# Altium schematic sheet Main.SchDoc(Main)
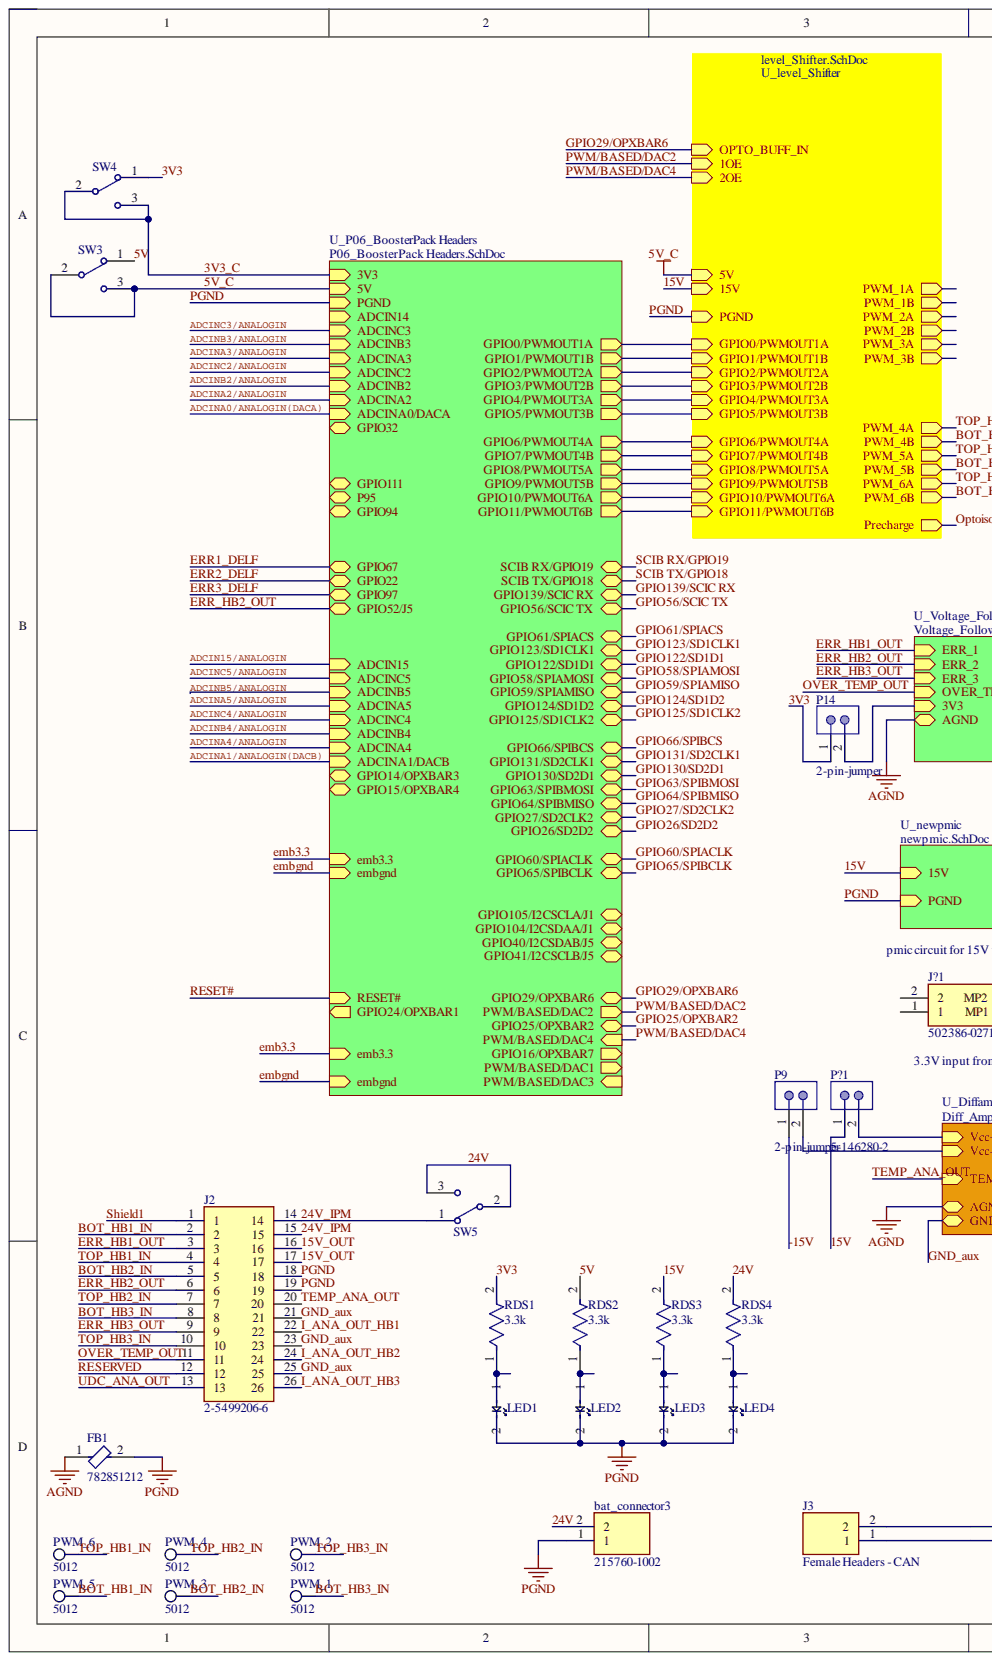
[diagram p0: Main.SchDoc, left]
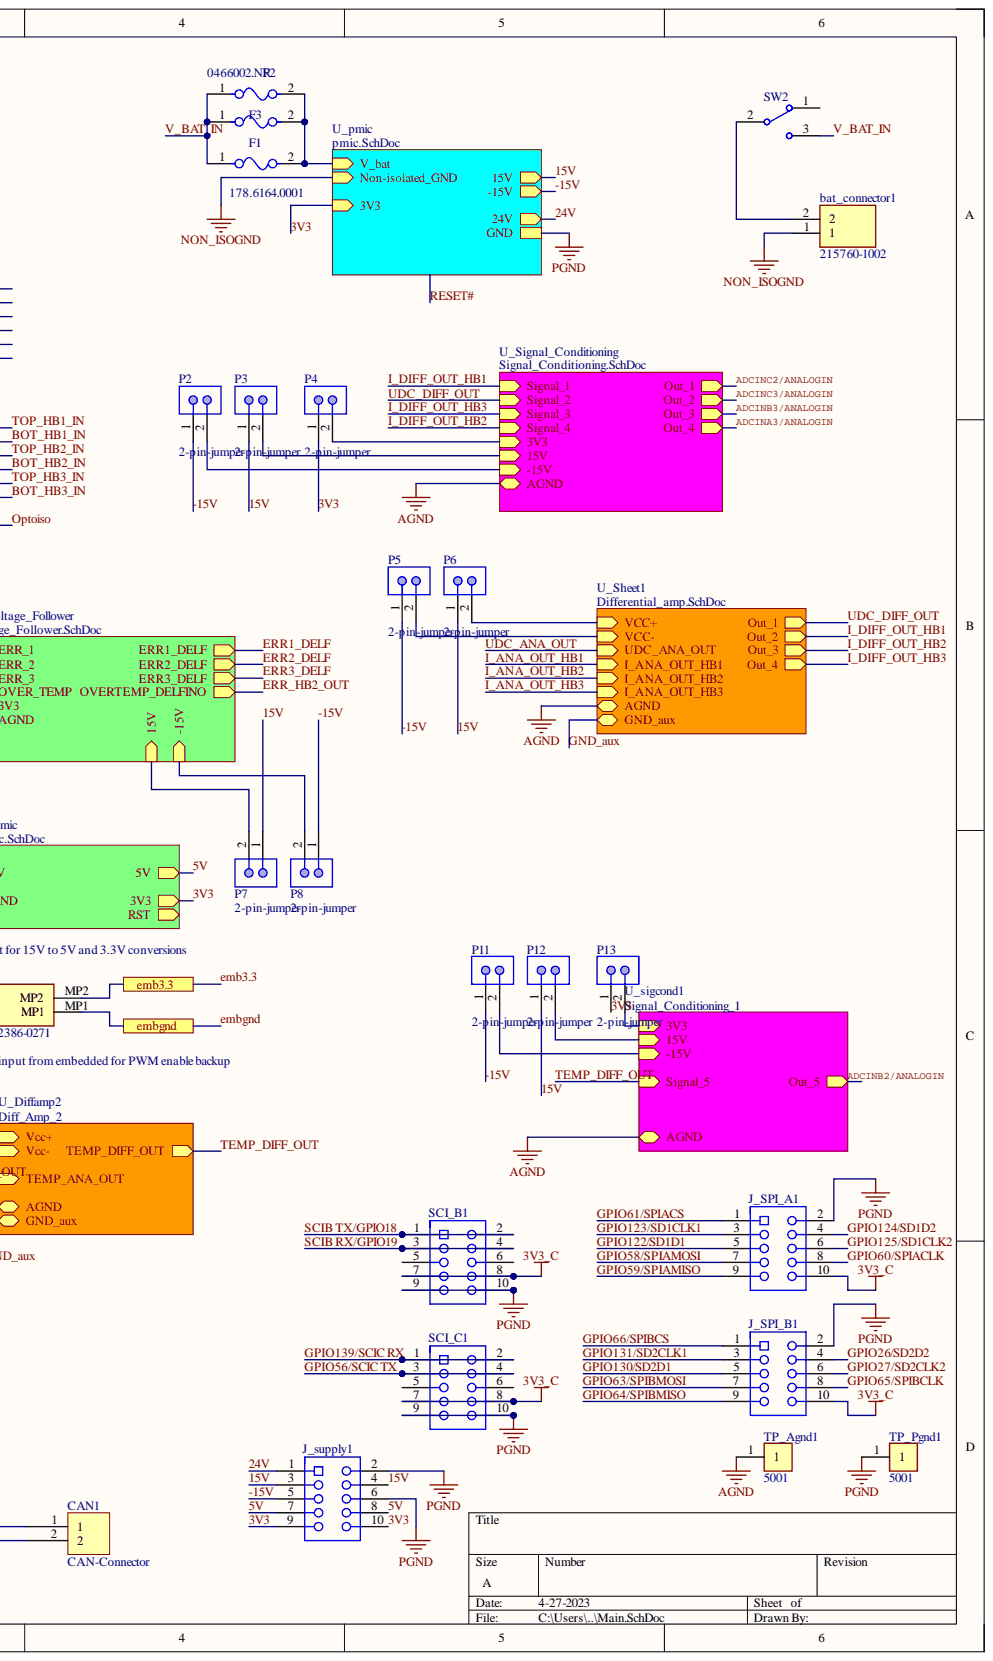
[diagram p1: Main.SchDoc, right]

## Parts
bat_connector1  215760-1002  [215760-YY02]
bat_connector3  215760-1002  [215760-YY02]
CAN1  CAN-Connector  [PCBComponent_1]
F1  178.6164.0001  [FP-178_6164_0001-MFG]
F2  0466002.NR  [FP-0466-MFG]
F3  0466002.NR  [FP-0466-MFG]
FB1  782851212  [FP-FBMH3225-MFG]
J2  2-5499206-6  [2-5499206-6]
J3  Female Headers - CAN  [RHDR2W80P0X254_1X2_558X254X850P]
J?1  502386-0271  [5023860271]
J_SPI_A1  61301021821  [61301021821]  (value not drawn)
J_SPI_B1  61301021821  [61301021821]  (value not drawn)
J_supply1  61301021821  [61301021821]  (value not drawn)
LED1  LED_1  [DIOC3225X06N]
LED2  LED_1  [DIOC3225X06N]
LED3  LED_1  [DIOC3225X06N]  (value not drawn)
LED4  LED_1  [DIOC3225X06N]  (value not drawn)
P2  2-pin-jumper  [TECO-5-146280-2_V]
P3  2-pin-jumper  [TECO-5-146280-2_V]
P4  2-pin-jumper  [TECO-5-146280-2_V]
P5  2-pin-jumper  [TECO-5-146280-2_V]
P6  2-pin-jumper  [TECO-5-146280-2_V]
P7  2-pin-jumper  [TECO-5-146280-2_V]
P8  2-pin-jumper  [TECO-5-146280-2_V]
P9  2-pin-jumper  [TECO-5-146280-2_V]
P11  2-pin-jumper  [TECO-5-146280-2_V]
P12  2-pin-jumper  [TECO-5-146280-2_V]
P13  2-pin-jumper  [TECO-5-146280-2_V]
P14  2-pin-jumper  [TECO-5-146280-2_V]
P?1  5-146280-2  [TECO-5-146280-2_V]
PWM_1  5012  [KSTN-5012_V]
PWM_2  5012  [KSTN-5012_V]
PWM_3  5012  [KSTN-5012_V]
PWM_4  5012  [KSTN-5012_V]
PWM_5  5012  [KSTN-5012_V]
PWM_6  5012  [KSTN-5012_V]
RDS1  3.3k  [FP-RP732A-IPC_A]
RDS2  3.3k  [FP-RP732A-IPC_A]
RDS3  3.3k  [FP-RP732A-IPC_A]
RDS4  3.3k  [FP-RP732A-IPC_A]
SCI_B1  61301021821  [61301021821]  (value not drawn)
SCI_C1  61301021821  [61301021821]  (value not drawn)
SW2  EG1218  [ESWI-EG1218_V]  (value not drawn)
SW3  EG1218  [ESWI-EG1218_V]  (value not drawn)
SW4  EG1218  [ESWI-EG1218_V]  (value not drawn)
SW5  EG1218  [ESWI-EG1218_V]  (value not drawn)
TP_Agnd1  5001  [FP-5001-MFG]
TP_Pgnd1  5001  [FP-5001-MFG]

## Ports
emb3.3, embgnd

## Nets
AGND: FB1.1 TP_Agnd1.1
NON_ISOGND: bat_connector1.1
3V3: J_supply1.9 J_supply1.10 P4.1 P13.1 P14.1 RDS1.2 SW4.1
3V3_C: J_SPI_A1.10 J_SPI_B1.10 SCI_B1.7 SCI_B1.8 SCI_C1.7 SCI_C1.8 SW4.2 SW4.3
5V: J_supply1.7 J_supply1.8 RDS2.2 SW3.1
5V_C: SW3.2 SW3.3
15V: J_supply1.3 J_supply1.4 P3.1 P6.1 P7.1 P12.1 P?1.1 RDS3.2
15V_OUT: J2.16 J2.17
24V: bat_connector3.2 J_supply1.1 RDS4.2 SW5.2 SW5.3
24V_IPM: J2.14 J2.15 SW5.1
-15V: J_supply1.5 P2.1 P5.1 P8.1 P9.1 P11.1
BOT_HB1_IN: J2.2 PWM_5.1
BOT_HB2_IN: J2.5 PWM_3.1
BOT_HB3_IN: J2.8 PWM_1.1
emb3.3: J?1.MP2 ; port emb3.3
embgnd: J?1.MP1 ; port embgnd
ERR_HB1_OUT: J2.3
ERR_HB2_OUT: J2.6
ERR_HB3_OUT: J2.9
GND_aux: J2.21 J2.23 J2.25
GPIO26/SD2D2: J_SPI_B1.4
GPIO27/SD2CLK2: J_SPI_B1.6
GPIO56/SCIC TX: SCI_C1.3 SCI_C1.4
GPIO58/SPIAMOSI: J_SPI_A1.7
GPIO59/SPIAMISO: J_SPI_A1.9
GPIO60/SPIACLK: J_SPI_A1.8
GPIO61/SPIACS: J_SPI_A1.1
GPIO63/SPIBMOSI: J_SPI_B1.7
GPIO64/SPIBMISO: J_SPI_B1.9
GPIO65/SPIBCLK: J_SPI_B1.8
GPIO66/SPIBCS: J_SPI_B1.1
GPIO122/SD1D1: J_SPI_A1.5
GPIO123/SD1CLK1: J_SPI_A1.3
GPIO124/SD1D2: J_SPI_A1.4
GPIO125/SD1CLK2: J_SPI_A1.6
GPIO130/SD2D1: J_SPI_B1.5
GPIO131/SD2CLK1: J_SPI_B1.3
GPIO139/SCIC RX: SCI_C1.1 SCI_C1.2
I_ANA_OUT_HB1: J2.22
I_ANA_OUT_HB2: J2.24
I_ANA_OUT_HB3: J2.26
OVER_TEMP_OUT: J2.11
PGND: bat_connector3.1 FB1.2 J2.18 J2.19 J_SPI_A1.2 J_SPI_B1.2 J_supply1.2 J_supply1.6 LED1.2 LED2.2 LED3.2 LED4.2 SCI_B1.9 SCI_B1.10 SCI_C1.9 SCI_C1.10 TP_Pgnd1.1
RESERVED: J2.12
SCIB RX/GPIO19: SCI_B1.3 SCI_B1.4
SCIB TX/GPIO18: SCI_B1.1 SCI_B1.2
Shield1: J2.1
TEMP_ANA_OUT: J2.20
TOP_HB1_IN: J2.4 PWM_6.1
TOP_HB2_IN: J2.7 PWM_4.1
TOP_HB3_IN: J2.10 PWM_2.1
UDC_ANA_OUT: J2.13
V_BAT_IN: F1.1 F2.1 F3.1 SW2.3
Netbat_connector1_2: bat_connector1.2 SW2.2
NetCAN1_1: CAN1.1 J3.2
NetCAN1_2: CAN1.2 J3.1
NetF1_2: F1.2 F2.2 F3.2
NetJ?1_1: J?1.1
NetJ?1_2: J?1.2
NetLED1_1: LED1.1 RDS1.1
NetLED2_1: LED2.1 RDS2.1
NetLED3_1: LED3.1 RDS3.1
NetLED4_1: LED4.1 RDS4.1
NetP2_2: P2.2
NetP3_2: P3.2
NetP4_2: P4.2
NetP5_2: P5.2
NetP6_2: P6.2
NetP7_2: P7.2
NetP8_2: P8.2
NetP9_2: P9.2
NetP11_2: P11.2
NetP12_2: P12.2
NetP13_2: P13.2
NetP14_2: P14.2
NetP?1_2: P?1.2
NetSCI_B1_5: SCI_B1.5
NetSCI_B1_6: SCI_B1.6
NetSCI_C1_5: SCI_C1.5
NetSCI_C1_6: SCI_C1.6
NetSW2_1: SW2.1
ADCIN14:
ADCIN15/ANALOGIN:
ADCINA0/ANALOGIN(DACA):
ADCINA1/ANALOGIN(DACB):
ADCINA2/ANALOGIN:
ADCINA3/ANALOGIN:
ADCINA4/ANALOGIN:
ADCINA5/ANALOGIN:
ADCINB2/ANALOGIN:
ADCINB3/ANALOGIN:
ADCINB4/ANALOGIN:
ADCINB5/ANALOGIN:
ADCINC2/ANALOGIN:
ADCINC3/ANALOGIN:
ADCINC4/ANALOGIN:
ADCINC5/ANALOGIN:
ERR1_DELF:
ERR2_DELF:
ERR3_DELF:
GPIO0/PWMOUT1A:
GPIO1/PWMOUT1B:
GPIO2/PWMOUT2A:
GPIO3/PWMOUT2B:
GPIO4/PWMOUT3A:
GPIO5/PWMOUT3B:
GPIO6/PWMOUT4A:
GPIO7/PWMOUT4B:
GPIO8/PWMOUT5A:
GPIO9/PWMOUT5B:
GPIO10/PWMOUT6A:
GPIO11/PWMOUT6B:
GPIO14/OPXBAR3:
GPIO15/OPXBAR4:
GPIO16/OPXBAR7:
GPIO24/OPXBAR1:
GPIO25/OPXBAR2:
GPIO29/OPXBAR6:
GPIO32:
GPIO40/I2CSDAB/J5:
GPIO41/I2CSCLB/J5:
GPIO94:
GPIO104/I2CSDAA/J1:
GPIO105/I2CSCLA/J1:
GPIO111:
I_DIFF_OUT_HB1:
I_DIFF_OUT_HB2:
I_DIFF_OUT_HB3:
Optoiso:
OVERTEMP_DELF:
P95:
PWM/BASED/DAC1:
PWM/BASED/DAC2:
PWM/BASED/DAC3:
PWM/BASED/DAC4:
PWM_1A:
PWM_1B:
PWM_2A:
PWM_2B:
PWM_3A:
PWM_3B:
RST:
TEMP_DIFF_OUT:
UDC_DIFF_OUT:

## Library data of the parts
bat_connector1 bat_connector3 = 215760-1002: Connector; Manufacturer_Name: Molex; Component Kind: Standard; Datasheet Link: https://www.molex.com/pdm_docs/sd/2157601002_sd.pdf; Height: 5.87mm; Manufacturer_Part_Number: 215760-1002; Mouser Part Number: 538-215760-1002; Mouser Price/Stock: https://www.mouser.co.uk/ProductDetail/Molex/215760-1002?qs=aP1CjGhiNiEyk5e8wZq4IA%3D%3D
CAN1 = CMP-002-000015-3: Amphenol CAN Connector; Component Kind: Standard; Design Item ID: Component_1; Source: Schlib1.SchLib; Library Name: Electrical TAH 6.0
F1 = CMP-08604-000013-1: FUSE HOLDER BLADE 80V PCB; Manufacturer: Littelfuse Inc; Conn Orientation: Vertical; Number Of Fuses: 1; Automotive: No; Category: Fuse; Component Kind: Standard; ComponentLink2Description: Datasheet; ComponentLink2URL: https://www.littelfuse.com/~/media/commercial-vehicle/datasheets/automotive-fuse-holders/ato/littelfuse-fuse-holder-ato-flr-pcb-datasheet.pdf; Device Class L1: Circuit Protection; Device Class L2: Fuse Holders; Device Class L3: unset; Digikey Description: FUSE HOLDER BLADE 80V PCB; Height: 17.5mm; Lead Free: Yes; Library Name: Altium Content Vault; Manufacturer Part Number: 178.6164.0001; Mouser Description: Fuse Holder HOLDER FOR FLR (ATO) PCB 1-PIN; Octopart: https://octopart.com/178.6164.0001-littelfuse-44502275; Package: 0; RoHS: TRUE; Temperature: to +130°C; Temperature Range High: +130°C; Voltage Rating Ac: 80V; Voltage Rating Dc: 80V
F2 F3 = CMP-2000-05742-2: FUSE BOARD MNT 2A 63VAC/VDC 1206; Manufacturer: Littelfuse; Fuse Blow Speed: Fast; Fuse I2T: 0.2326A2Sec; Fuse Interrupt Ac Current: 50A; Fuse Voltage Drop: 78.73mV; Max Fuse Ac Voltage: 63V; Resistance: 0.03Ω; Value: 0.03Ω; Automotive: No; Category: Fuse; Component Kind: Standard; ComponentLink2Description: Datasheet; ComponentLink2URL: https://www.littelfuse.com/~/media/electronics/datasheets/fuses/littelfuse_fuse_466_datasheet.pdf.pdf; Device Class L1: Circuit Protection; Device Class L2: Fuses; Device Class L3: unset; Digikey Description: FUSE BOARD MNT 2A 63VAC/VDC 1206; Fuse Current: 2A; Fuse Interrupt Dc Current: 50A; Height: 0.66mm; Lead Free: Yes; Library Name: Altium Content Vault; Manufacturer Part Number: 0466002.NR; Max Fuse Dc Voltage: 63V; Mouser Description: 466 Series Very Fast-Acting 63 V 2 A 1206 Surface Mount Thin Film Fuse; Octopart: https://octopart.com/0466002.nr-littelfuse-566006; Package: 0466; RoHS: TRUE; Temperature: -55°C to +90°C; Temperature Range High: +90°C; Temperature Range Low: -55°C; Voltage Rating: 63V
FB1 = CMP-14488-000303-2: FERRITE BEAD 600 OHM 1210 1LN; DC Resistance (DCR): 42mR; Impedance: 600R; Max Current Rating: 3A; Number of Lines: 1; Test Frequency: 100MHz; Tolerance: 30%; Case Code (Imperial): 1210; Case Code (Metric): 3225; Case/Package: 1210; Component Kind: Standard; Height: 0.11inch; Length: 0.126inch; Library Name: Altium Content Vault; Max DC Current: 3A; Max Operating Temperature: 125°C; Min Operating Temperature: -40°C; Mount: Surface Mount; Package Quantity: 1000; Packaging: Tape and Reel; Ratings: AEC-Q200; Resistance: 600R; RoHS Compliant: Yes; Termination: SMD/SMT; Weight: 0.000564oz; Width: 2.5mm
J2 = 2-5499206-6: Connector; Manufacturer_Name: TE Connectivity; Arrow Part Number: 2-5499206-6; Arrow Price/Stock: https://www.arrow.com/en/products/2-5499206-6/te-connectivity; Component Kind: Standard; Datasheet Link: https://componentsearchengine.com/Datasheets/2/2-5499206-6.pdf; Library Name: SamacSys.SchLib; Manufacturer_Part_Number: 2-5499206-6; Mouser Part Number: 571-2-5499206-6; Mouser Price/Stock: https://www.mouser.co.uk/ProductDetail/TE-Connectivity/2-5499206-6?qs=0WAkd%2F2UZ9QLA5bMxSIPKw%3D%3D
J3 = PPPC021LFBN-RC: Manufacturer_Name: Sullins; Component Kind: Standard; Datasheet Link: http://www.sullinscorp.com/drawings/78_P(N)PxCxxxLFBN-RC,_10492-H.pdf; Description: PPPC021LFBN-RC; Height: 8.5mm; Manufacturer_Part_Number: PPPC021LFBN-RC
J?1 = 502386-0271: Connector; Manufacturer_Name: Molex; Arrow Part Number: 502386-0271; Arrow Price/Stock: https://www.arrow.com/en/products/5023860271/molex; Component Kind: Standard; Datasheet Link: http://www.molex.com/webdocs/datasheets/pdf/en-us//5023860271_PCB_RECEPTACLES.pdf; Height: 5.75mm; Manufacturer_Part_Number: 502386-0271; Mouser Part Number: 538-502386-0271; Mouser Price/Stock: https://www.mouser.co.uk/ProductDetail/Molex/502386-0271?qs=eKig3M7YXu%252B%252BLfQPrNmJKA%3D%3D
J_SPI_A1 J_SPI_B1 J_supply1 SCI_B1 SCI_C1 = CMP-1502-01334-1: THT Vertical Socket Header WR-PHD, Pitch 2.54 mm, Dual Row, 10 pins; Manufacturer: Wurth Elektronik; Contact Resistance: 20mOhm; Gender: Female; Pin Number: 10; Pitch: 2.54mm; Rated Current: 3A; Withstanding Voltage: 500VAC; Working Voltage: 250VAC; Component Kind: Standard; Datasheet URL: https://katalog.we-online.de/em/datasheet/6130xx21821.pdf; Library Name: Altium Content Vault; Manufacturer URL: http://www.we-online.de; Mounting Technology: THT; Operating Temperature: -40to125degC; Orientation: Vertical; Package Reference: 61301021821; Packaging: Tray; Part Number: 61301021821; Row Number: Dual; Publisher: WurthElektronik
LED1-LED4 = Component_1: Component Kind: Standard; Library Name: LED_1206.SchLib
P2-P9 P11-P14 P?1 = CMP-001-0000-1: Conn Unshrouded Header HDR 2 POS 2.54mm Solder ST Top Entry Thru-Hole Carton 5-146280-2; Component Kind: Standard; Library Name: Electrical TAH 6.0
PWM_1-PWM_6 = CMP-1672-00004-4: Test Point, White, Through Hole, RoHS, Bulk; Manufacturer: Keystone; Colour: White; Component Kind: Standard; ComponentLink1Description: Manufacturer URL; ComponentLink1URL: http://www.keyelco.com/; ComponentLink2Description: Datasheet; ComponentLink2URL: http://www.keyelco.com/product-pdf.cfm?p=1321; Contact Material: Bronze; Contact Plating: Silver; DatasheetVersion: Rev. F, 12/2013; Height: 8.12 mm; Length: 11.3 mm; Library Name: Altium Content Vault; Mount: Through Hole; Mounting Technology: Through Hole; Package Quantity: 100; PackageDescription: 1-Pin Through Hole Device, Dia 3.17 mm; PackageReference: 5012; Packaging: Bulk; Pins: 1; REACH SVHC: No SVHC; RoHSCompliant: true
RDS1-RDS4 = CMP-03211-009998-2: Surface Mount Resistors, Precision Resistor, 2 Terminations, Size Code 0805, Cut Tape Length, .1%, Thin Film, 10K 0.1% 10PPM; Number of Resistors: 1; Power Rating: 125mW; Resistance: 1kR; Temperature Coefficient: 15ppm/°C; Voltage Rating: 150V; Case Code (Imperial): 0805; Case Code (Metric): 2012; Case/Package: 0805; Component Kind: Standard; Composition: Thin Film; ELV: Compliant; Height: 0.55mm; Length: 2mm; Library Name: Altium Content Vault; Max Operating Temperature: 155°C; Min Operating Temperature: -55°C; Mount: Surface Mount; Number of Pins: 2; Number of Terminations: 2; Package Quantity: 250; Packaging: Cut Tape; Termination: Solder; Tolerance: 0.1%; Voltage Rating (DC): 150V; Weight: 0.000194oz; Width: 1.25mm
SW2-SW5 = CMP-1696-00002-1: Slide Switch, 500 V, -20 to 70 degC, 3-Pin THD, RoHS; Case\Package: SOT-23-5; Dropout Voltage: 300 mV; Max Input Voltage: 8 V; Max Ouput Current: 150 mA; Max Power Dissipation: 250 mW; Min Input Voltage: 4.3 V; Nominal Output Voltage: 3.3 V; Outputs: 1; Component Kind: Standard; ComponentLink1Description: Manufacturer URL; ComponentLink1URL: http://www.e-switch.ch/en/; ComponentLink2Description: Datasheet; ComponentLink2URL: https://www.e-switch.com/system/asset/product_line/data_sheet/119/EG.pdf; DatasheetVersion: Oct-15; Height: 1.3 mm; Length: 3 mm; Library Name: Altium Content Vault; Max Operating Temperature: 85 degC; Min Operating Temperature: -40 degC; Mount: Surface Mount; Output Current: 150 mA; Output Voltage: 3.3 V; Package Quantity: 3000; PackageDescription: 3-Pin Through Hole Device, Body 11.6 x 4 mm, Pitch 2.5 mm; PackageReference: EG1218; Packaging: Tape and Reel; Pins: 5; Power Rating: 250 mW; Radiation Hardening: No; REACH SVHC: No SVHC; RoHSCompliant: true; Width: 1.8 mm
TP_Agnd1 TP_Pgnd1 = CMP-2000-05181-2: PC TEST POINT MINIATURE BLACK; Body Material: Bronze; Hole Diameter: 1.6mm; Inside Diameter: 1.27mm; Insulation: Insulated; Number of Contacts: 1; Outside Diameter: 2.54mm; Plating: Silver; Color: Black; Component Kind: Standard; Contact Material: Bronze; Contact Plating: Silver; Diameter: 2.54mm; Flammability Rating: UL94 V-0; Height: 0.3inch; Housing Color: Black; Insulation Material: Nylon; Lead Free: Lead Free; Length: 7.62mm; Library Name: Altium Content Vault; Material: Nylon; Mount: Through Hole; Number of Ports: 1; Orientation: Straight; Package Quantity: 100; Packaging: Bulk; REACH SVHC: No SVHC; RoHS Compliant: Yes; Series: THM; Termination: Through Hole
